# Altium schematic sheet Sheet2.SchDoc(Sheet2)
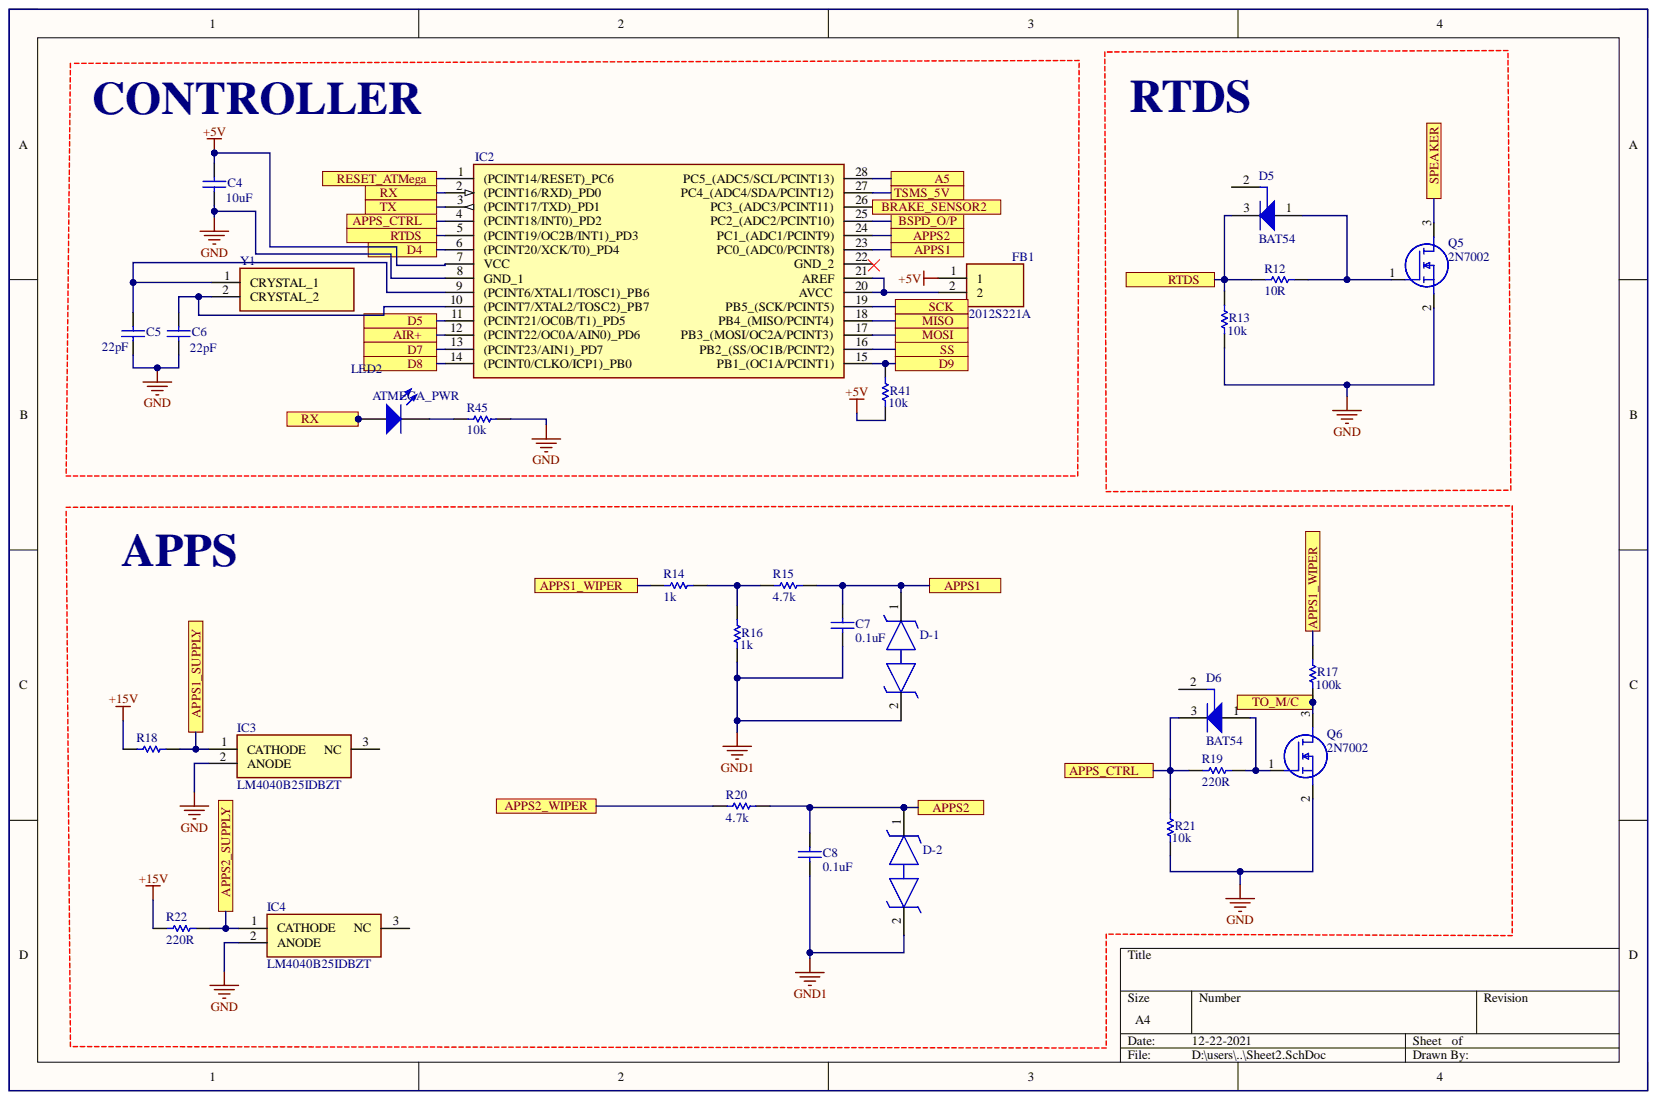
[diagram p0: Sheet2.SchDoc]

## Parts
ATMEGA_PWR  LED2  [3.2X1.6X1.1]
C4  10uF  [KEMT-1206-2_N]
C5  22pF  [KEMT-1206-2_N]
C6  22pF  [KEMT-1206-2_N]
C7  0.1uF  [KEMT-1206-2_N]
C8  0.1uF  [KEMT-1206-2_N]
D5  BAT54  [INF-SOT23_N]
D6  BAT54  [INF-SOT23_N]
D-1  TPD1E6B06DPLR  [R1206]  (value not drawn)
D-2  TPD1E6B06DPLR  [R1206]  (value not drawn)
FB1  MPZ2012S221A  [BEADC2012X105N]
IC2  ATMEGA328-PU  [DIP1016W53P254L3468H457Q28N]  (value not drawn)
IC3  LM4040B25IDBZT  [SOT95P237X112-3N]
IC4  LM4040B25IDBZT  [SOT95P237X112-3N]
Q5  2N7002  [SOT96P240X120-3N]
Q6  2N7002  [SOT96P240X120-3N]
R12  10R  [RESC3216X60X45NL10T20 - duplicate]
R13  10k  [RESC3216X60X45NL10T20 - duplicate]
R14  1k  [RESC3216X60X45NL10T20 - duplicate]
R15  4.7k  [RESC3216X60X45NL10T20 - duplicate]
R16  1k  [RESC3216X60X45NL10T20 - duplicate]
R17  100k  [RESC3216X60X45NL10T20 - duplicate]
R18  CRCW120647K0FKEA  [RESC3216X60X45NL10T20 - duplicate]  (value not drawn)
R19  220R  [RESC3216X60X45NL10T20 - duplicate]
R20  4.7k  [RESC3216X60X45NL10T20 - duplicate]
R21  10k  [RESC3216X60X45NL10T20 - duplicate]
R22  220R  [RESC3216X60X45NL10T20 - duplicate]
R41  10k  [RESC3216X60X45NL10T20 - duplicate]
R45  10k  [RESC3216X60X45NL10T20 - duplicate]
Y1  ECS-160-18-4X-CKM  [ECS160184XCKM]  (value not drawn)

## Ports
A5, AIR+, APPS1, APPS1_SUPPLY, APPS1_WIPER, APPS2, APPS2_SUPPLY, APPS2_WIPER, APPS_CTRL, BRAKE_SENSOR2, BSPD_O/P, D4, D5, D7, D8, D9, MISO, MOSI, RESET_ATMega, RTDS, RX, SCK, SPEAKER, SS, TO_M/C, TSMS_5V, TX

## Nets
+5V: C4.2 FB1.1 IC2.7(VCC) R41.1
+15V: R18.2 R22.2
GND: C4.1 C5.1 C6.1 IC2.8(GND_1) IC3.2 IC4.2 Q5.2 Q6.2 R13.1 R21.1 R45.1
GND1: C7.1 C8.1 D-1.2 D-2.2 R16.1
A5: IC2.28(PC5_(ADC5/SCL/PCINT13)) ; port A5
AIR+: IC2.12((PCINT22/OC0A/AIN0)_PD6) ; port AIR+
APPS1: C7.2 D-1.1 IC2.23(PC0_(ADC0/PCINT8)) R15.1 ; port APPS1
APPS1_SUPPLY: IC3.1 R18.1 ; port APPS1_SUPPLY
APPS1_WIPER: R14.2 R17.2 ; port APPS1_WIPER
APPS2: C8.2 D-2.1 IC2.24(PC1_(ADC1/PCINT9)) R20.1 ; port APPS2
APPS2_SUPPLY: IC4.1 R22.1 ; port APPS2_SUPPLY
APPS2_WIPER: R20.2 ; port APPS2_WIPER
APPS_CTRL: D6.3 IC2.4((PCINT18/INT0)_PD2) R19.2 R21.2 ; port APPS_CTRL
BRAKE_SENSOR2: IC2.26(PC3_(ADC3/PCINT11)) ; port BRAKE_SENSOR2
BSPD_O/P: IC2.25(PC2_(ADC2/PCINT10)) ; port BSPD_O/P
D4: IC2.6((PCINT20/XCK/T0)_PD4) ; port D4
D5: IC2.11((PCINT21/OC0B/T1)_PD5) ; port D5
D7: IC2.13((PCINT23/AIN1)_PD7) ; port D7
D8: IC2.14((PCINT0/CLKO/ICP1)_PB0) ; port D8
D9: IC2.15(PB1_(OC1A/PCINT1)) R41.2 ; port D9
MISO: IC2.18(PB4_(MISO/PCINT4)) ; port MISO
MOSI: IC2.17(PB3_(MOSI/OC2A/PCINT3)) ; port MOSI
RESET_ATMega: IC2.1((PCINT14/RESET)_PC6) ; port RESET_ATMega
RTDS: D5.3 IC2.5((PCINT19/OC2B/INT1)_PD3) R12.2 R13.2 ; port RTDS
RX: ATMEGA_PWR.1 IC2.2((PCINT16/RXD)_PD0) ; port RX
SCK: IC2.19(PB5_(SCK/PCINT5)) ; port SCK
SPEAKER: Q5.3 ; port SPEAKER
SS: IC2.16(PB2_(SS/OC1B/PCINT2)) ; port SS
TO_M/C: Q6.3 R17.1 ; port TO_M/C
TSMS_5V: IC2.27(PC4_(ADC4/SDA/PCINT12)) ; port TSMS_5V
TX: IC2.3((PCINT17/TXD)_PD1) ; port TX
NetATMEGA_PWR_2: ATMEGA_PWR.2 R45.2
NetC5_2: C5.2 IC2.9((PCINT6/XTAL1/TOSC1)_PB6) Y1.1
NetC6_2: C6.2 IC2.10((PCINT7/XTAL2/TOSC2)_PB7) Y1.2
NetD5_1: D5.1 Q5.1 R12.1
NetD6_1: D6.1 Q6.1 R19.1
NetFB1_2: FB1.2 IC2.20(AVCC) IC2.21(AREF)
NetR14_1: R14.1 R15.2 R16.2

Not connected: D5 2; D6 2; IC2 22(GND_2); IC3 3; IC4 3

## Library data of the parts
ATMEGA_PWR = LED2: Typical RED, GREEN, YELLOW, AMBER GaAs LED; Component Kind: Standard; LatestRevisionDate: 17-Jul-2002; LatestRevisionNote: Re-released for DXP Platform.; Library Name: Miscellaneous Devices.IntLib; PackageDescription: SM LED; 2 Flat Leads; PackageReference: 3.2X1.6X1.1; PackageVersion: Feb-2002; Published: 8-Jun-2000; Simulation: LED2; Publisher: Altium Limited
C4-C8 = CMP-1037-04202-2: CAP, Ceramic, 0.1 uF, +/- 10%, 50 V, -55 to 125 degC, 1206 (3216 Metric), RoHS, Tape and Reel; Manufacturer: Kemet; Capacitance: 0.1µF; Capacitor Case Style: 1206[3216Metric]; Dielectric Characteristic: X7R; Product Range: KEMET-CSeries; SVHC: ToBeAdvised; Voltage Rating: 50V; Capacitance Tolerance: ±10%; Component Kind: Standard; Datasheet URL: https://datasheet.ciiva.com/6125/1725438-6125494.pdf; Datasheet Version: Jul-13; Manufacturer URL: http://www.kemet.com/; Operating Temperature Max: 125degC; Operating Temperature Min: -55degC; Package Description: 2-Leads SMD, Body 3.2 x 1.6 mm; Package Reference: 1206-2; Packaging: Tape&Reel
  C7 C8: Library Name: Schlib1.SchLib
  C4-C6: Library Name: Schlib1_TSAL_LV_3.1.SchLib
D5 D6 = CMP-1182-00010-1: Silicon Schottky Diode, SOT23, Reel, Green; Manufacturer: Infineon; Configuration: Single; CT (max): 10Â pF; Green: TRUE; IF (max): 200Â mA; VF (max): 800Â mV; VR (max): 30Â V; Component Kind: Standard; ComponentLink1Description: Manufacturer URL; ComponentLink1URL: http://www.infineon.com/; ComponentLink2Description: Datasheet; ComponentLink2URL: http://www.infineon.com/dgdl/bat54series.pdf?folderId=db3a30431400ef68011425f1ca2505e3&fileId=db3a304314dca3890115190b74210ea6; ComponentLink3Description: Package Specification; ComponentLink3URL: http://www.infineon.com/dgdl/SOT23-Package_Overview.pdf?folderId=db3a30431936bc4b0119532290053c66&fileId=db3a30431936bc4b0119533468123c85; Library Name: Schlib1.SchLib; Mounting Technology: Surface Mount; PackageDescription: 3-Pin Small Outline Transistor Package, 1.3 x 2.9 mm Body, 0.95 mm Pitch; PackageReference: SOT23; PackageVersion: Apr-08; Packing: Reel; PartNumber: BAT54
FB1 = MPZ2012S221A: Ferrite Bead; Manufacturer_Name: TDK; Component Kind: Standard; Datasheet Link: https://product.tdk.com/info/en/catalog/datasheets/beads_commercial_power_mpz2012_en.pdf; Height: 1.05mm; Library Name: SamacSys.SchLib; Manufacturer_Part_Number: MPZ2012S221A; Mouser Part Number: 810-MPZ2012S221A; Mouser Price/Stock: https://www.mouser.co.uk/ProductDetail/TDK/MPZ2012S221A?qs=g8DL0B6GkTmmIcDMLnw6hw%3D%3D
IC2 = ATMEGA328-PU: Integrated Circuit; Manufacturer_Name: Microchip; Arrow Part Number: ATMEGA328-PU; Arrow Price/Stock: https://www.arrow.com/en/products/atmega328-pu/microchip-technology; Component Kind: Standard; Datasheet Link: https://componentsearchengine.com/Datasheets/1/ATMEGA328-PU.pdf; Height: 4.572mm; Library Name: SamacSys.SchLib; Manufacturer_Part_Number: ATMEGA328-PU; Mouser Part Number: 556-ATMEGA328-PU; Mouser Price/Stock: https://www.mouser.co.uk/ProductDetail/Microchip-Technology-Atmel/ATMEGA328-PU?qs=lwdSMh1%2FoYJT8tfItQpQtA%3D%3D
IC3 IC4 = LM4040B25IDBZT: Integrated Circuit; Manufacturer_Name: Texas Instruments; Arrow Part Number: LM4040B25IDBZT; Arrow Price/Stock: https://www.arrow.com/en/products/lm4040b25idbzt/texas-instruments; Component Kind: Standard; Datasheet Link: https://www.ti.com/lit/gpn/LM4040; Height: 1.12mm; Library Name: SamacSys.SchLib; Manufacturer_Part_Number: LM4040B25IDBZT; Mouser Part Number: 595-LM4040B25IDBZT; Mouser Price/Stock: https://www.mouser.com/Search/Refine.aspx?Keyword=595-LM4040B25IDBZT
Q5 Q6 = 2N7002: MOSFET (N-Channel); Manufacturer_Name: Vishay; Component Kind: Standard; Datasheet Link: https://www.diodes.com/assets/Datasheets/ds11303.pdf; Height: 1.2mm; Library Name: SamacSys.SchLib; Manufacturer_Part_Number: 2N7002; Mouser Part Number: 781-2N7002; Mouser Price/Stock: https://www.mouser.co.uk/ProductDetail/Vishay-Siliconix/2N7002?qs=xBpwZ0JX2zKeuvZKtdYLZQ%3D%3D
R12-R17 R19-R22 R41 R45 = CMP-2003-02213-1: Manufacturer: Vishay Dale; Part Number: CRCW120647K0FKEA; Component Kind: Standard; Library Name: Schlib1.SchLib
R18 = CMP-2003-02213-1: Manufacturer: Vishay Dale; Component Kind: Standard; Library Name: Schlib1.SchLib; Part Number: CRCW120647K0FKEA
Y1 = ECS-160-18-4X-CKM: Crystal or Oscillator; Manufacturer_Name: ECS; Component Kind: Standard; Datasheet Link: https://www.mouser.be/datasheet/2/122/hc-49usx-791.pdf; Height: 3.5mm; Library Name: SamacSys.SchLib; Manufacturer_Part_Number: ECS-160-18-4X-CKM; Mouser Part Number: 520-ECS160-18-4X-CKM; Mouser Price/Stock: https://www.mouser.co.uk/ProductDetail/ECS/ECS-160-18-4X-CKM?qs=7MVldsJ5UawYqyimirccKw%3D%3D
D-1 D-2 = TPD1E6B06DPLR: Component Kind: Standard; Library Name: Diode_Texas_Instruments_TPD1E6B06DPLR_eec.SchLib
